# Altium schematic sheet pwrCorePri.SchDoc(pwrCorePri)
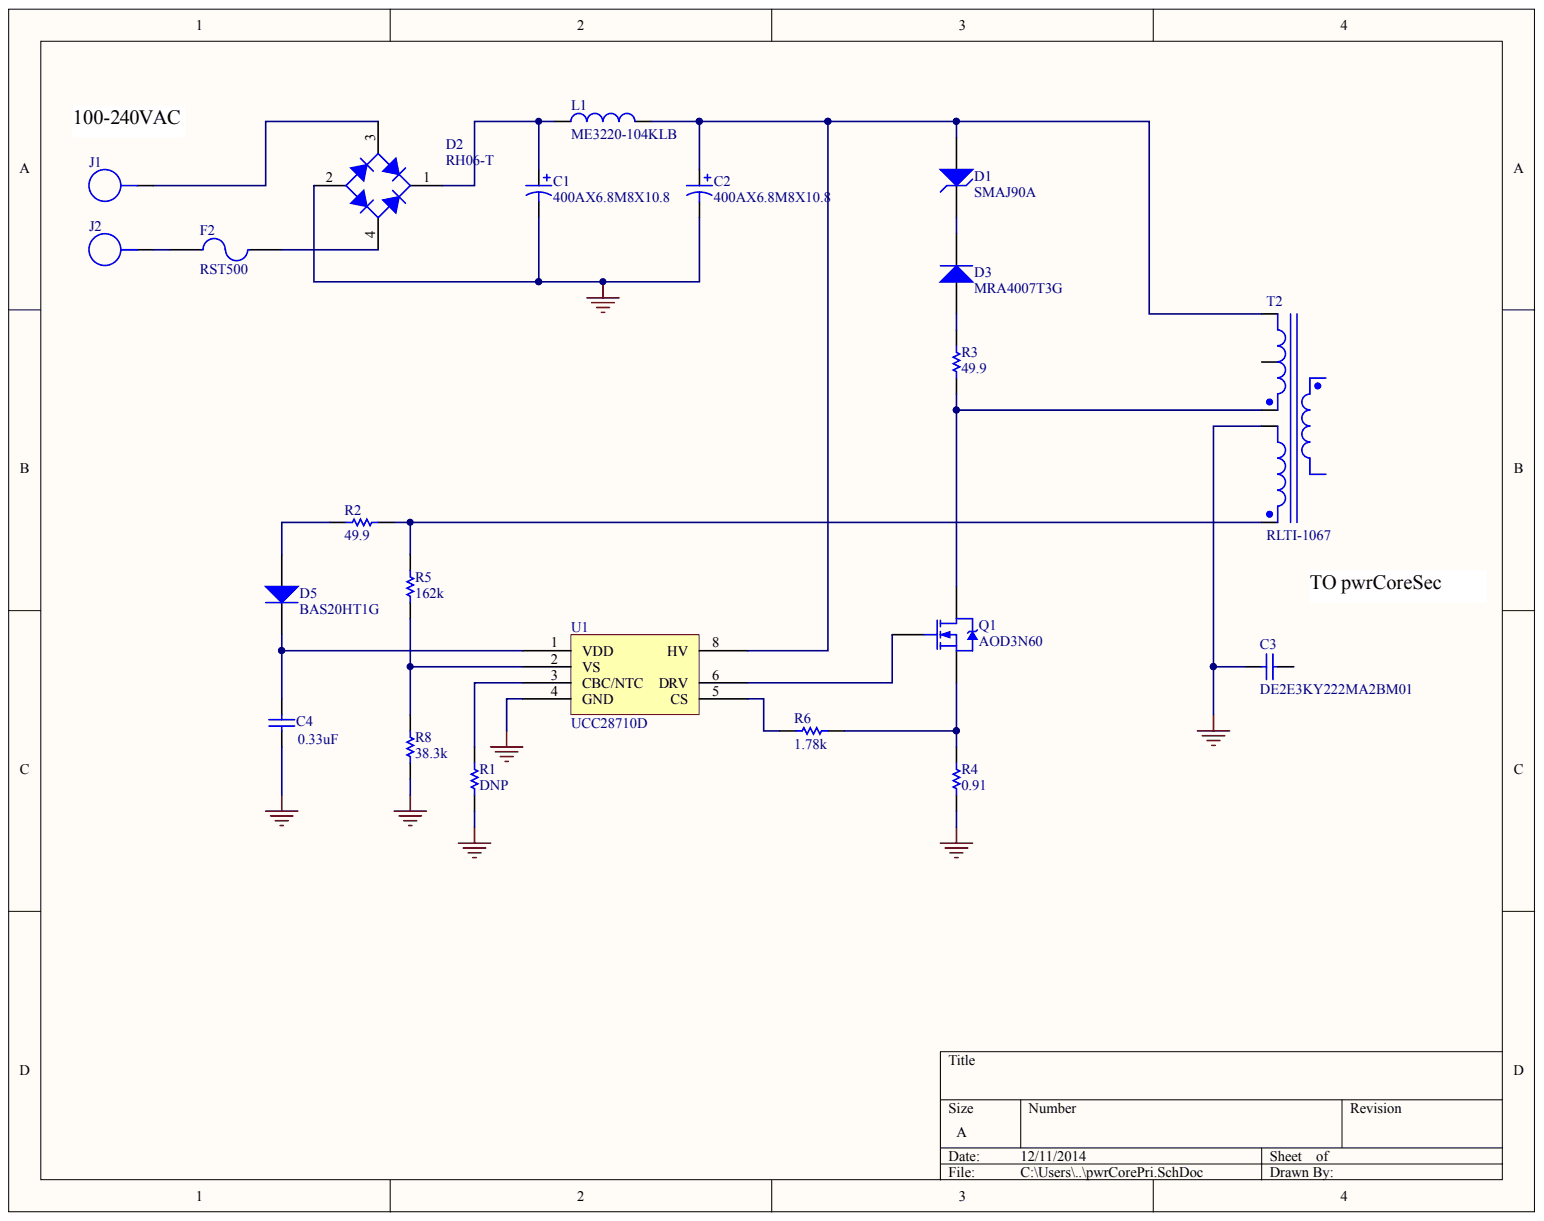
[diagram p0: pwrCorePri.SchDoc]

## Parts
C1  400AX6.8M8X10.8
C2  400AX6.8M8X10.8
C3  DE2E3KY222MA2BM01
C4  value 0.33uF  (hidden comment: smd_capacitor)
D1  SMAJ90A
D2  RH06-T
D3  MRA4007T3G
D5  BAS20HT1G
F2  RST500
J1  24_Gauge_Through  (value not drawn)
J2  24_Gauge_Through  (value not drawn)
L1  ME3220-104KLB
Q1  AOD3N60
R1  value DNP  (hidden comment: smd_resistor)
R2  value 49.9  (hidden comment: smd_resistor)
R3  value 49.9  (hidden comment: smd_resistor)
R4  value 0.91  (hidden comment: smd_resistor)
R5  value 162k  (hidden comment: smd_resistor)
R6  value 1.78k  (hidden comment: smd_resistor)
R8  value 38.3k  (hidden comment: smd_resistor)
T2  RLTI-1067
U1  UCC28710D

## Nets
GND: C1.2 C2.2 C3.1 C4.1 D2.2 R1.1 R4.1 R8.1 T2.4 U1.4(GND)
NetC1_1: C1.1 D2.1 L1.1
NetC2_1: C2.1 D1.1 L1.2 T2.1 U1.8(HV)
NetC4_2: C4.2 D5.2 U1.1(VDD)
NetD1_2: D1.2 D3.2
NetD2_3: D2.3 J1.1
NetD2_4: D2.4 F2.2
NetD3_1: D3.1 R3.2
NetD5_1: D5.1 R2.1
NetF2_1: F2.1 J2.1
NetQ1_1: Q1.1 U1.6(DRV)
NetQ1_2: Q1.2 R4.2 R6.2
NetQ1_3: Q1.3 R3.1 T2.3
NetR1_2: R1.2 U1.3(CBC/NTC)
NetR2_2: R2.2 R5.2 T2.5
NetR5_1: R5.1 R8.2 U1.2(VS)
NetR6_1: R6.1 U1.5(CS)
N00019:

Not connected: T2 2

## Library data of the parts
C1-C4 D1-D3 D5 F2 J1 J2 L1 Q1 R1-R6 R8 T2 U1 = (no symbol name)
  C1 C2: Digikey: 1189-2277-ND
  C3: Digikey: 490-4231-ND
  C4: Digikey: 445-5950-1-ND
  D1: Digikey: SMAJ90ALFCT-ND
  D2: Digikey: RH06DICT-ND
  D3: Digikey: MRA4007T3GOSCT-ND
  D5: Digikey: BAS20HT1GOSCT
  F2: Digikey: 507-1722-3-ND
  Q1: Digikey: 785-1181-1-ND
  R1: Digikey: ??
  R2: Digikey: 311-49.9LRCT-ND
  R3: Digikey: 311-49.9FRCT-ND
  R4: Digikey: 311-.91SCT-ND
  R5: Digikey: RMCF0402FT162KCT-ND
  R6: Digikey: 541-1.78KLCT-ND
  R8: Digikey: 541-38.3KLCT-ND
  U1: Digikey: 296-35537-5-ND
